# Altium schematic sheet TOP.SchDoc(TOP)
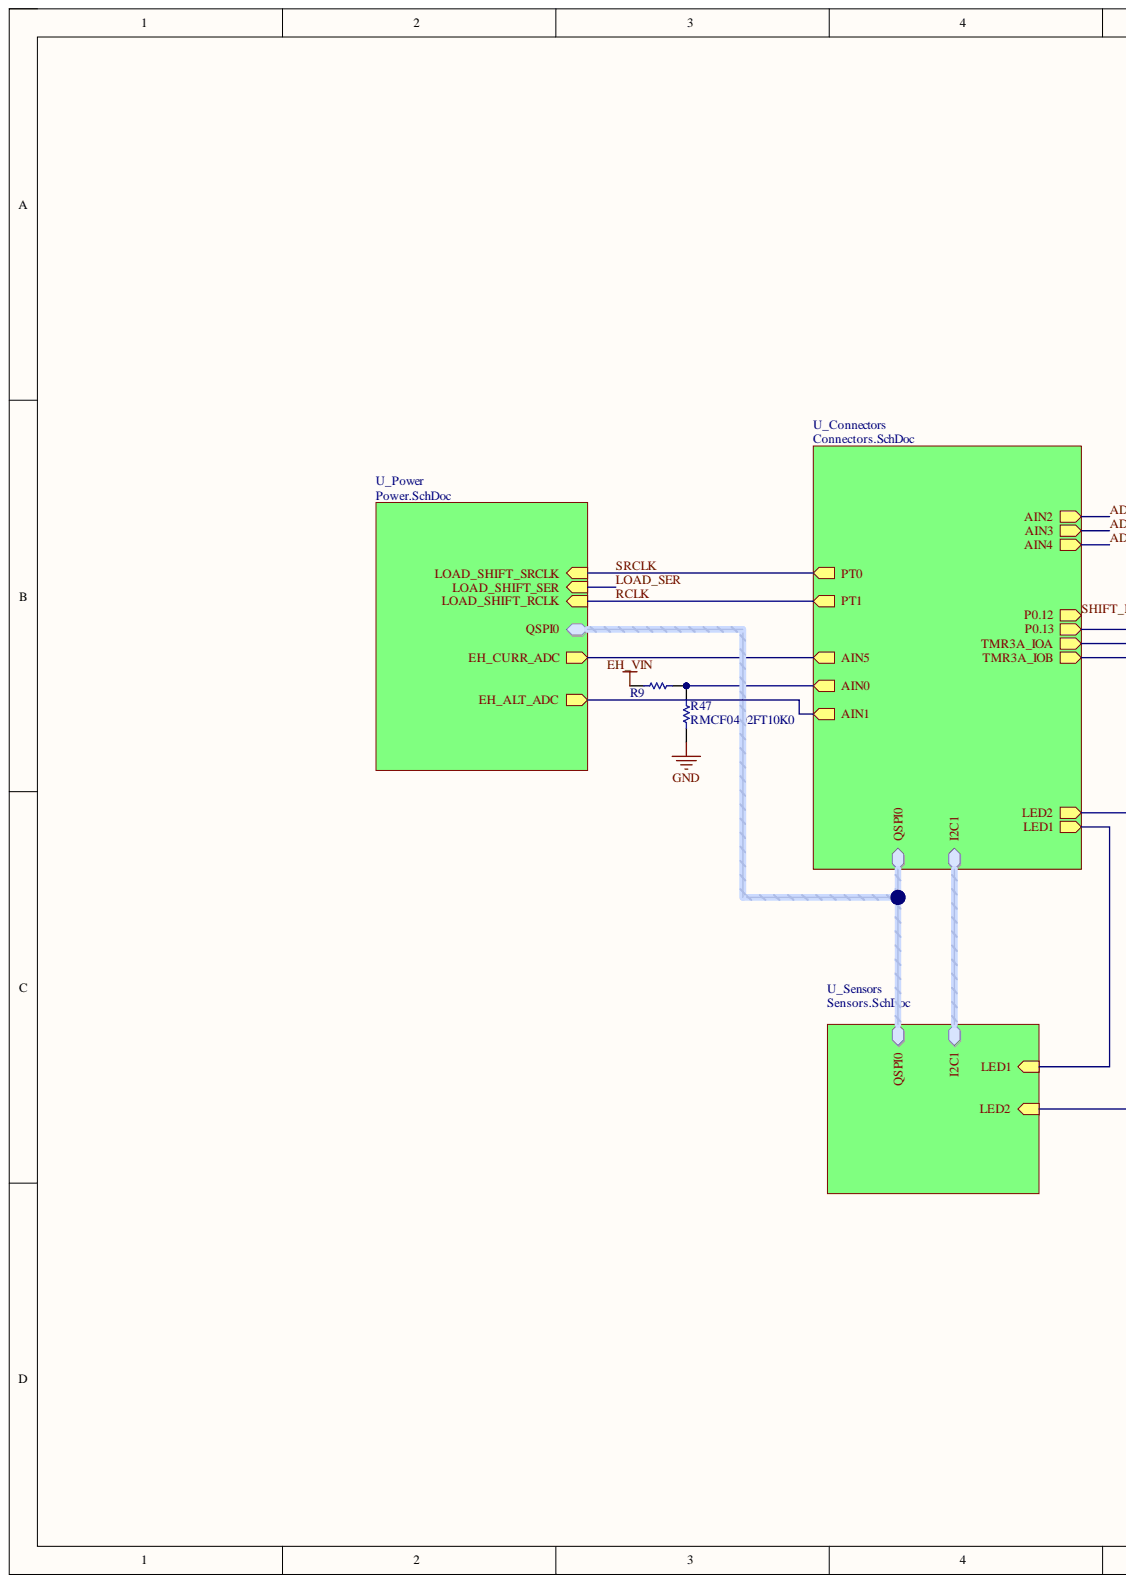
[diagram p0: TOP.SchDoc, left]
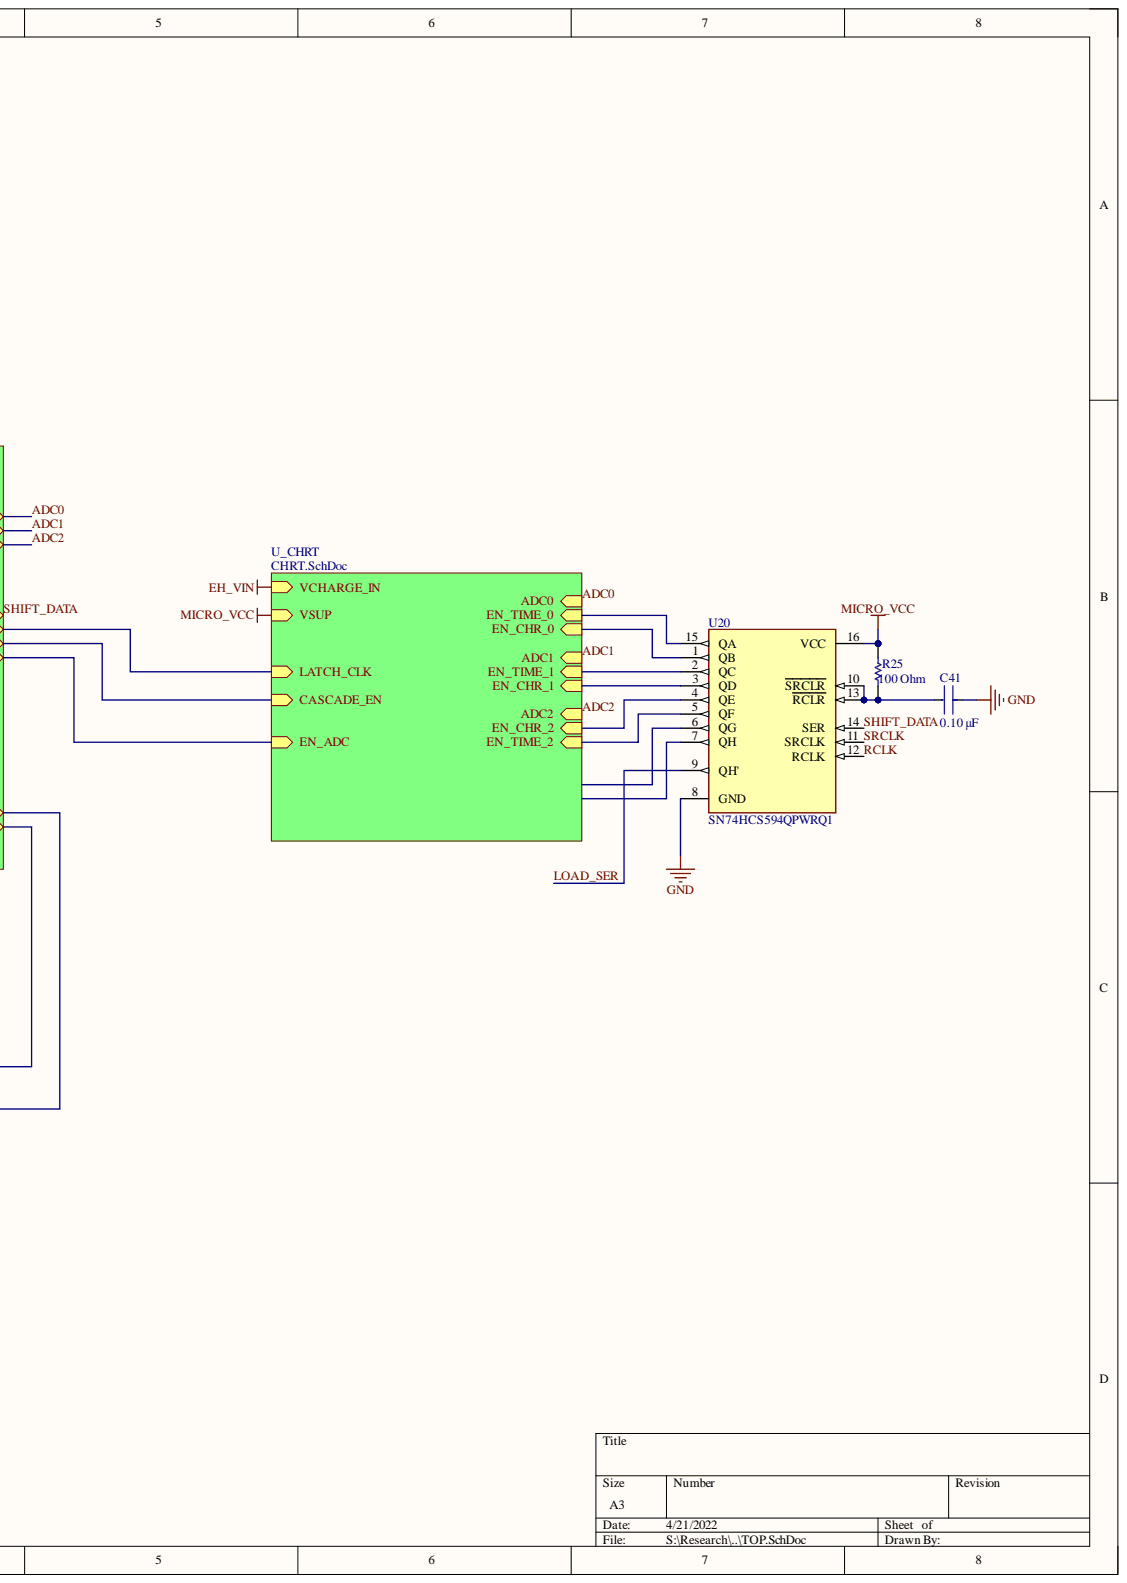
[diagram p1: TOP.SchDoc, right]

## Parts
C41  value 0.10 μF  Capacitance: 0.10 μF  [FP-C0402-BB-MFG]  (hidden comment: C0402C104K8PACTU)
R9  RC0402JR-075M6L  [RESC1005X40X25ML05T10]  (value not drawn)
R25  Resistance: 100 Ohm  [RESC1005X40X25LL05T05]  (hidden comment: ERJ-2RKF1000X)
R47  RMCF0402FT10K0  [RESC1005X40X25ML05T10]
U20  SN74HCS594QPWRQ1  [FP-PW0016A-IPC_A]

## Nets
EH_VIN: R9.1
GND: C41.1 R47.1 U20.8(GND)
MICRO_VCC: R25.2 U20.16(VCC)
LOAD_SER: U20.9(QH')
RCLK: U20.12(RCLK)
SHIFT_DATA: U20.14(SER)
SRCLK: U20.11(SRCLK)
NetC41_2: C41.2 R25.1 U20.10(SRCLR) U20.13(RCLR)
NetR9_2: R9.2 R47.2
NetU20_1: U20.1(QB)
NetU20_2: U20.2(QC)
NetU20_3: U20.3(QD)
NetU20_4: U20.4(QE)
NetU20_5: U20.5(QF)
NetU20_6: U20.6(QG)
NetU20_7: U20.7(QH)
NetU20_15: U20.15(QA)
ADC0:
ADC1:
ADC2:
AIN1:
AIN5:
CASCADE_EN:
EN_ADC:
I2C1.SCL:
I2C1.SDA:
LATCH_CLK:
LED1:
LED2:
QSPI0.MISO:
QSPI0.MOSI:
QSPI0.SCK:
QSPI0.SDIO2:
QSPI0.SDIO3:
QSPI0.SS0:
QSPI0.SS1:
QSPI0.SS2:

## Library data of the parts
C41 = CMP-2008-02046-2: CAP CER 0.1UF 10V X5R 0402; Manufacturer: Kemet; Material: Ceramic; Temperature Coefficient: 15%; Tolerance: 0.1; Automotive: No; Category: Cap; Component Kind: Standard; ComponentLink2Description: Datasheet; ComponentLink2URL: https://content.kemet.com/datasheets/KEM_C1002_X7R_SMD.pdf; Device Class L1: Passive Components; Device Class L2: Capacitors; Device Class L3: Ceramic Capacitors; Digikey Description: CAP CER 0.1UF 10V X5R 0402; Height: 0.55mm; Ipc Land Pattern Name: CAPC10050X50; Lead Free: Yes; Library Name: Altium Content Vault; Manufacturer Part Number: C0402C104K8PACTU; Mouser Description: Capacitor 100 nF 10 VDC 0402; Octopart: https://octopart.com/c0402c104k8pactu-kemet-140039; Package: C0402-BB; RoHS: TRUE; Temperature: -55°C to +125°C; Temperature Characteristic: X5R; Temperature Range High: +125°C; Temperature Range Low: -55°C; Voltage Rating: 10 V
R9 = CMP-2002-07139-1: Manufacturer: Yageo / Phycomp; Component Kind: Standard; Library Name: Altium Content Vault; Part Number: RC0402JR-075M6L
R25 = CMP-2002-00605-3: Chip Resistor, 100 Ohm, +/- 1%, 100 mW, -55 to 155 degC, 0402 (1005 Metric), RoHS, Tape and Reel; Manufacturer: Basic Passives; Case\/Package: 0402; Power Rating: 100 mW; Voltage Rating: 50 V; Case Code (Imperial): 0402; Case Code (Metric): 1005; Component Kind: Standard; ComponentLink1Description: Manufacturer URL; ComponentLink1URL: http://www.panasonic.com/; ComponentLink2Description: Datasheet; ComponentLink2URL: http://industrial.panasonic.com/cdbs/www-data/pdf/RDA0000/AOA0000C86.pdf; DatasheetVersion: 04/2015; Height: 0.35 mm; Length: 1 mm; Library Name: Altium Content Vault; Max Operating Temperature: 155 degC; Min Operating Temperature: -55 degC; Mount: Surface Mount; Mounting Technology: Surface Mount; Package Quantity: 10000; PackageDescription: 2-Pin Surface Mount Device, Body 1 x 0.5 mm; PackageReference: 0402; Packaging: Tape and Reel; PartNumber: ERJ-2RKF1000X; Pins: 2; Radiation Hardening: No; REACH SVHC: Yes; RoHSCompliant: true; Tolerance: 1%; Width: 0.5 mm
R47 = CMP-2002-07490-1: Manufacturer: Yageo / Phycomp; Part Number: RC0402FR-071ML; Component Kind: Standard; Library Name: Altium Content Vault
U20 = CMP-04904-000006-1: AUTOMOTIVE 8-BIT SHIFT REGISTERS; Component Kind: Standard; Library Name: Altium Content Vault
